# Altium schematic sheet Control Board.SchDoc("Control Board")
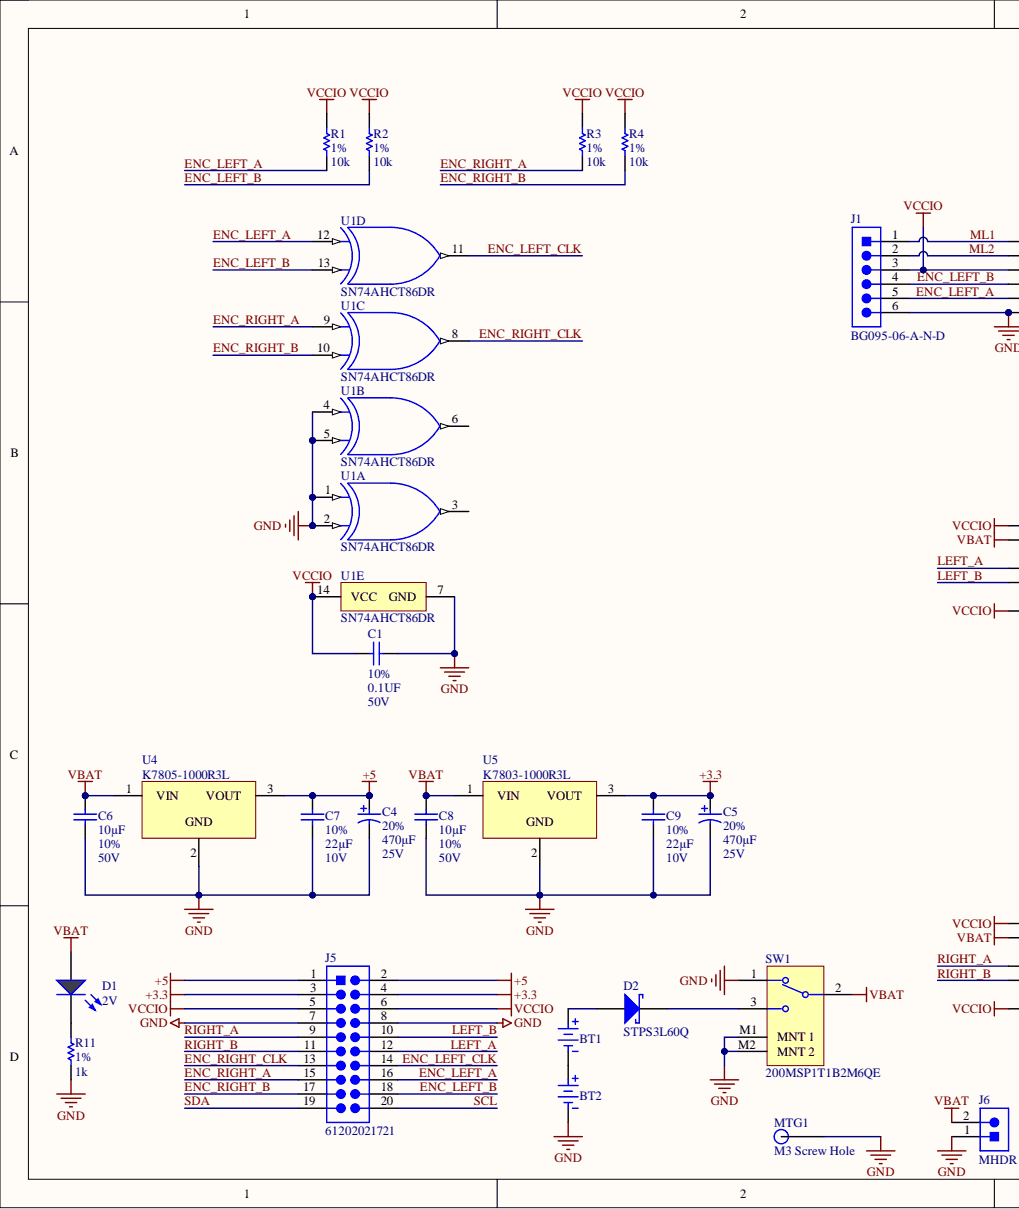
[diagram p0: Control Board.SchDoc, left]
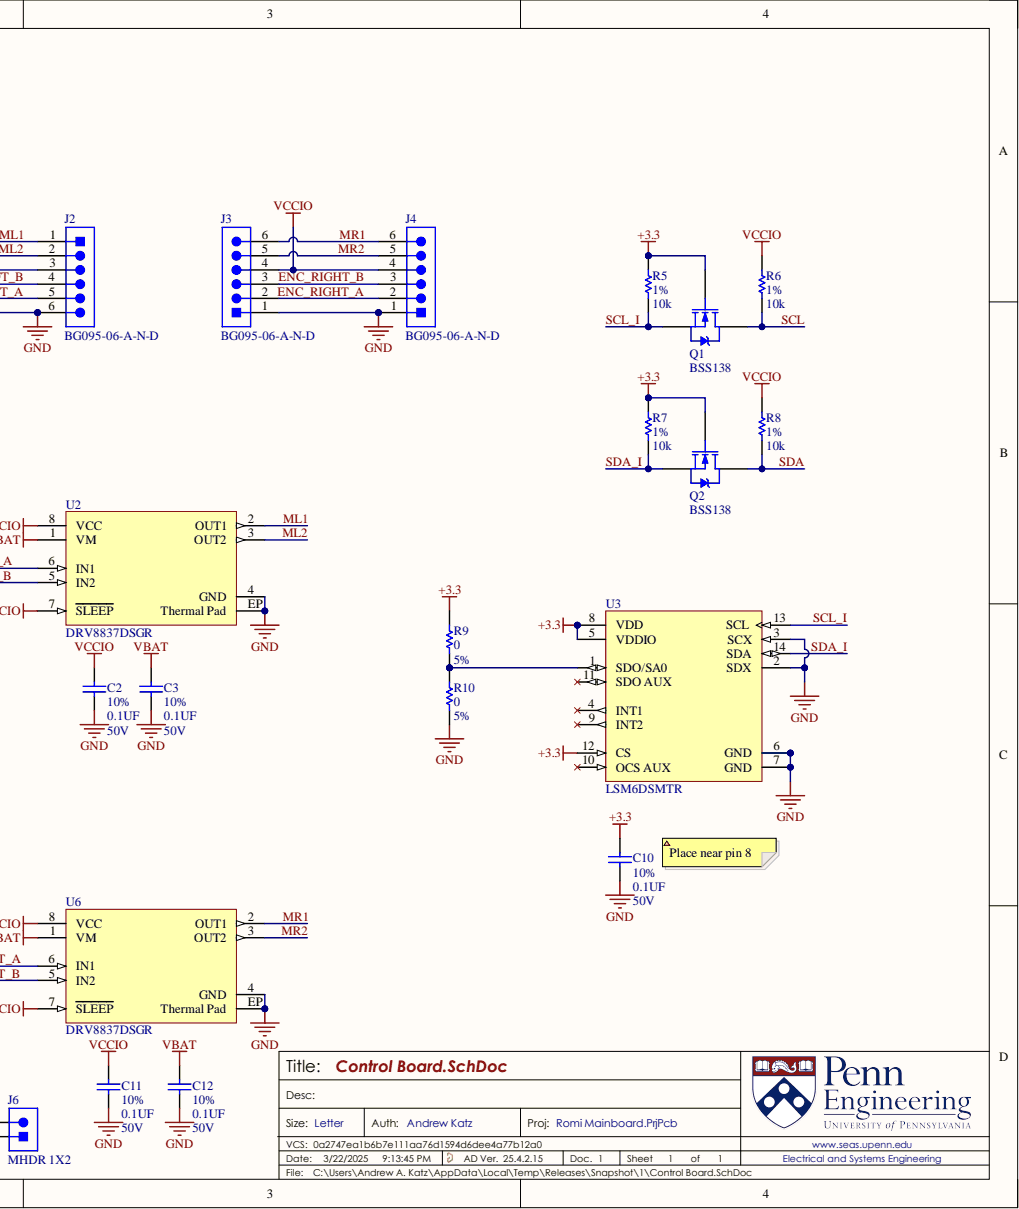
[diagram p1: Control Board.SchDoc, right]

## Parts
BT1  Romi Battery Terminals  [ROMI_BATT]  (value not drawn)
BT2  Romi Battery Terminals  [ROMI_BATT]  (value not drawn)
C1  value 0.1UF  Tolerance: 10%  [0603_CAP]  (hidden comment: CAP 0603 0.1UF 50V 10% X7R)
C2  value 0.1UF  Tolerance: 10%  Voltage: 50V  [0603_CAP]  (hidden comment: CAP 0603 0.1UF 50V 10% X7R)
C3  value 0.1UF  Tolerance: 10%  Voltage: 50V  [0603_CAP]  (hidden comment: CAP 0603 0.1UF 50V 10% X7R)
C4  value 470µF  Tolerance: 20%  Voltage: 25V  [NicCap_10x12.5]  (hidden comment: UVR1H471MHD)
C5  value 470µF  Tolerance: 20%  Voltage: 25V  [NicCap_10x12.5]  (hidden comment: UVR1H471MHD)
C6  value 10µF  Tolerance: 10%  [1206_CAP]  (hidden comment: CAP 1206 10UF 50V 10% X5R)
C7  value 22µF  Tolerance: 10%  Voltage: 10V  [1206_CAP_75H]  (hidden comment: CAP 1206 22UF 10V 10% X7R)
C8  value 10µF  Tolerance: 10%  [1206_CAP]  (hidden comment: CAP 1206 10UF 50V 10% X5R)
C9  value 22µF  Tolerance: 10%  Voltage: 10V  [1206_CAP_75H]  (hidden comment: CAP 1206 22UF 10V 10% X7R)
C10  value 0.1UF  Tolerance: 10%  Voltage: 50V  [0603_CAP]  (hidden comment: CAP 0603 0.1UF 50V 10% X7R)
C11  value 0.1UF  Tolerance: 10%  Voltage: 50V  [0603_CAP]  (hidden comment: CAP 0603 0.1UF 50V 10% X7R)
C12  value 0.1UF  Tolerance: 10%  Voltage: 50V  [0603_CAP]  (hidden comment: CAP 0603 0.1UF 50V 10% X7R)
D1  Forward Voltage: 2V  [LED_0805]  (hidden comment: LSM0805452V)
D2  STPS3L60Q  [DO-15_P0.5in_Horizontal]
J1  BG095-06-A-N-D  [BG095-06-A-N-D-Footprint-1]
J2  BG095-06-A-N-D  [BG095-06-A-N-D-Footprint-1]
J3  BG095-06-A-N-D  [BG095-06-A-N-D-Footprint-1]
J4  BG095-06-A-N-D  [BG095-06-A-N-D-Footprint-1]
J5  61202021721  [FP-61202021721-MFG]
J6  MHDR 1X2  [PH1-02-UA]
MTG1  M3 Screw Hole  [M3 Screw Hole-Footprint-1]  (no BOM)
Q1  BSS138  [SOT-23-3_ONSEMI]
Q2  BSS138  [SOT-23-3_ONSEMI]
R1  value 10k  Tolerance: 1%  [0603_RES]  (hidden comment: RES 0603 10K 1%)
R2  value 10k  Tolerance: 1%  [0603_RES]  (hidden comment: RES 0603 10K 1%)
R3  value 10k  Tolerance: 1%  [0603_RES]  (hidden comment: RES 0603 10K 1%)
R4  value 10k  Tolerance: 1%  [0603_RES]  (hidden comment: RES 0603 10K 1%)
R5  value 10k  Tolerance: 1%  [0603_RES]  (hidden comment: RES 0603 10K 1%)
R6  value 10k  Tolerance: 1%  [0603_RES]  (hidden comment: RES 0603 10K 1%)
R7  value 10k  Tolerance: 1%  [0603_RES]  (hidden comment: RES 0603 10K 1%)
R8  value 10k  Tolerance: 1%  [0603_RES]  (hidden comment: RES 0603 10K 1%)
R9  value 0  Tolerance: 5%  [0603_RES]  (hidden comment: RES 0603 0 5%)
R10  value 0  Tolerance: 5%  [0603_RES]  (hidden comment: RES 0603 0 5%)
R11  value 1k  Tolerance: 1%  [0603_RES]  (hidden comment: RES 0603 1K 1%)
SW1  200MSP1T1B2M6QE  [FP-200MSP1T1B2M6QE-MFG]
U1  SN74AHCT86DR  [SOIC14 (D0014A_N)]  (parts A,B,C,D,E)
U2  DRV8837DSGR  [FP-DSG0008A-MFG]
U3  LSM6DSMTR  [FP-LGA-14L-DM00249496-MFG]
U4  K7805-1000R3L  [K78XX-1000R3L-Footprint-1]
U5  K7803-1000R3L  [K78XX-1000R3L-Footprint-1]
U6  DRV8837DSGR  [FP-DSG0008A-MFG]

## Nets
+3.3: C5.1 C9.2 C10.2 J5.3 J5.4 Q1.1 Q2.1 R5.2 R7.2 R9.2 U3.5(VDDIO) U3.8(VDD) U3.12(CS) U5.3
+5: C4.1 C7.2 J5.1 J5.2 U4.3
GND: BT2.2 C1.2 C2.1 C3.1 C4.2 C5.2 C6.1 C7.1 C8.1 C9.1 C10.1 C11.1 C12.1 J1.6 J2.6 J3.1 J4.1 J5.7 J5.8 J6.1 MTG1.1 R10.1 R11.1 SW1.1 SW1.M1(MNT_1) SW1.M2(MNT_2) U1.1 U1.2 U1.4 U1.5 U1.7(GND) U2.4(GND) U2.EP(Thermal_Pad) U3.2(SDX) U3.3(SCX) U3.6(GND) U3.7(GND) U4.2 U5.2 U6.4(GND) U6.EP(Thermal_Pad)
VBAT: C3.2 C6.2 C8.2 C12.2 D1.A J6.2 SW1.2 U2.1(VM) U4.1 U5.1 U6.1(VM)
VCCIO: C1.1 C2.2 C11.2 J1.3 J2.3 J3.4 J4.4 J5.5 J5.6 R1.2 R2.2 R3.2 R4.2 R6.2 R8.2 U1.14(VCC) U2.7(SLEEP) U2.8(VCC) U6.7(SLEEP) U6.8(VCC)
ENC_LEFT_A: J1.5 J2.5 J5.16 R1.1 U1.12
ENC_LEFT_B: J1.4 J2.4 J5.18 R2.1 U1.13
ENC_LEFT_CLK: J5.14 U1.11
ENC_RIGHT_A: J3.2 J4.2 J5.15 R3.1 U1.9
ENC_RIGHT_B: J3.3 J4.3 J5.17 R4.1 U1.10
ENC_RIGHT_CLK: J5.13 U1.8
LEFT_A: J5.12 U2.6(IN1)
LEFT_B: J5.10 U2.5(IN2)
ML1: J1.1 J2.1 U2.2(OUT1)
ML2: J1.2 J2.2 U2.3(OUT2)
MR1: J3.6 J4.6 U6.2(OUT1)
MR2: J3.5 J4.5 U6.3(OUT2)
RIGHT_A: J5.9 U6.6(IN1)
RIGHT_B: J5.11 U6.5(IN2)
SCL: J5.20 Q1.3 R6.1
SCL_I: Q1.2 R5.1 U3.13(SCL)
SDA: J5.19 Q2.3 R8.1
SDA_I: Q2.2 R7.1 U3.14(SDA)
NetBT1_1: BT1.1 D2.A
NetBT1_2: BT1.2 BT2.1
NetD1_K: D1.K R11.2
NetD2_K: D2.K SW1.3
NetR9_1: R9.1 R10.2 U3.1(SDO/SA0)

Not connected: U1 3 6; U3 4(INT1) 9(INT2) 10(OCS_AUX) 11(SDO_AUX)

## Library data of the parts
C1-C3 C10-C12 = CMP-011-000223-2: Capacitor Surface Mount 0603 Footprint 0.1UF 50V 10% Tolerance Ceramic X7R; Manufacturer: Various; Description in Visual: Cap SMT 0603 0.1UF 50V 10%  Cer X7R; PCB Package: 0603; Component Kind: Standard; JLCPCB Part #: C14663; Library Name: University of Pennsylvania (ESE Labs); Manufacturer P/N: CAP 0603 0.1UF 50V 10% X7R; Mount: SMT; P/N: CAP 0603 0.1UF 50V 10% X7R; Type: Ceramic X7R
  C1: Voltage: 50V
C4 C5 = CMP-00366-1: Capacitor, Aluminum Electrolytic, Cap 470uF 50V 20%, Radial 12.5X20 LS 5 mm; Capacitor Type: Aluminium; Leakage Current: 705uA; Polarity: Polarized; Ripple Current: 760mA; Tan Delta: 0.12; Case/Package: THT; Component Kind: Standard; Diameter: 12.5mm; Dielectric Material: Aluminium; JESD-609 Code: e3; Length: 20mm; Library Name: University of Pennsylvania (ESE Labs); Max Operating Temperature: 85°C; Min Operating Temperature: -40°C; Mount: THT; Mounting Technology: Through Hole; Package Shape: Cylindrical; Package Style: RadialMeter; Packaging: Bulk; Pins: 2; Rated DC Voltage (URdc): 50V; Reach SVHC Compliant: No; RoHS: Yes; RoHS Compliant: Yes; Terminal Finish: Tin; Terminal Pitch: 5mm; Termination: Wire; Tolerance  (fill in as +-): 20%; Tolerance (fill in as +-): 20%
C6 C8 = CMP-001-00003-3: Capacitor Surface Mount 1206 Footprint 10UF 25V 10% Tolerance Ceramic X5R; Manufacturer: Various; Description in Visual: Cap SMT 1206 10UF 50V 10%  Cer X5R; PCB Package: 1206; Capacitor Type: Ceramic; Case/Package: 1206; Component Kind: Standard; JLCPCB Part #: C13585; Library Name: University of Pennsylvania (ESE Labs); Manufacturer P/N: CAP 1206 10UF 50V 10% X5R; Mount: SMT; P/N: CAP 1206 10UF 50V 10% X5R; RoHS: Yes; Type: Ceramic X5R; Voltage: 50V
C7 C9 = CMP-011-000660-2: Capacitor Surface Mount 1206 Footprint 22UF 10V 10% Tolerance Ceramic X7R; Manufacturer: Various; Description in Visual: Cap SMT 1206 22UF 10V 10%  Cer X7R; PCB Package: 1206; Capacitor Type: Ceramic; Case/Package: 1206; Component Kind: Standard; JLCPCB Part #: C5672; Library Name: University of Pennsylvania (ESE Labs); Manufacturer P/N: CAP 1206 22UF 10V 10% X7R; Mount: SMT; P/N: CAP 1206 22UF 10V 10% X7R; RoHS: Yes; Type: Ceramic X7R
D1 = CMP-00038-3: Single Color LED, Green, 0805; Test Current: 20mA; Component Kind: Standard; JLCPCB Part #: C2297; Library Name: University of Pennsylvania (ESE Labs); RoHS Compliant: Yes
D2 = CMP-00365-1: Rectifier Diode Schottky 60V 3A 2-Pin DO-15 T/R; Application(s): POWER; Case Connection: Isolated; Diode Element Material: SILICON; Forward Voltage: 590mV; Non-rep Pk Forward Current-Max: 100A; Number of Elements: 1; Package Body Material: Plastic; Qualification Status: Not Qualified; Surface Mount: No; Component Kind: Standard; Diode Type: RECTIFIER; JEDEC-95 Code: DO-15; JESD-30 Code: O-PALF-W2; JESD-609 Code: e3; Library Name: University of Pennsylvania (ESE Labs); Max Operating Temperature: 150°C; Max Output current: 3A; Moisture Sensitivity Level: 1; Number of Phases: 1; Package Shape: Round; Package Style: LONG FORMMeter; Pins: 2; Reach SVHC Compliant: No; Rep Pk Reverse Voltage-Max: 60V; RoHS Compliant: Yes; Technology: SCHOTTKY; Terminal Finish: Tin; Terminal Form: Wire; Terminal Position: AXIAL
J1-J4 = CMP-00360-1: Board Connector, 6 Contact(s), 1 Row(s), Female, Straight, 0.1 inch Pitch, Solder Terminal, Locking Low Profile; Manufacturer Series: BG095; Body Depth: 0.197inch; Body Length: 0.62inch; Contact Pattern: RECTANGULAR; Contact Resistance: 20mR; Contact Style: SQ PIN-SKT; Dielectric Withstanding Voltage (AC): 600V; Insulation Resistance: 1GR; Number Of Connectors: 1; Number of Contacts: 6; Polarization Key: POLARIZED HOUSING; Rated Current (Signal): 3A; Reference Standard: UL; Reliability: COMMERCIAL; Body Breadth: 0.1inch; Component Kind: Standard; Connector Type: BOARD CONNECTOR; Contact Finish - Mating: AU; Contact Finish - Termination: Gold (Au) - with Nickel (Ni) barrier; Contact Gender: Female; Contact Material: Bronze; Insulation Material: Nylon; JESD-609 Code: e4; Library Name: University of Pennsylvania (ESE Labs); Mating Contact Pitch: 0.1inch; Max Operating Temperature: 105°C; Min Operating Temperature: -40°C; Mounting Option 1: LOCKING; Mounting Style: STRAIGHT; Mounting Technology: Board; Number Of PCB Rows: 1; Number of Rows Loaded: 1; PCB Contact Pattern: RECTANGULAR; Reach SVHC Compliant: Yes; RoHS Compliant: Yes; Terminal Length: 0.118inch; Terminal Pitch: 2.54mm; Termination: Solder
J5 = CMP-00359-1: Board Connector, 20 Contact(s), 2 Row(s), Male, Right Angle, 0.1 inch Pitch, Solder Terminal, Locking, Black Insulator; Body Breadth: 0.358inch; Body Depth: 0.521inch; Body Length: 1.302inch; Contact Pattern: RECTANGULAR; Contact Resistance: 20mR; Contact Style: SQ PIN-SKT; Dielectric Withstanding Voltage (AC): 500V; DIN Conformance: No; Insulation Resistance: 1GR; Number Of Connectors: 1; Number of Contacts: 20; Number Of PCB Rows: 2; Option: General Purpose; PCB Contact Row Spacing: 2.54mm; Polarization Key: POLARIZED HOUSING; Rated Current (Signal): 3A; Reference Standard: UL; Reliability: COMMERCIAL; Component Kind: Standard; Connector Type: BOARD CONNECTOR; Contact Finish - Mating: GOLD; Contact Finish - Termination: Nickel/Gold (Ni/Au); Contact Gender: Male; Contact Material: Copper Alloy; Filter Feature: No; Flammability Rating: UL94 V-0; IEC Conformance: No; Insulation Color: Black; Insulation Material: Polybutylene; JESD-609 Code: e4; Library Name: University of Pennsylvania (ESE Labs); Mating Contact Pitch: 0.1inch; Mating Contact Row Spacing: 0.1inch; Max Operating Temperature: 125°C; MIL Conformance: No; Min Operating Temperature: -40°C; Mixed Contacts: No; Mounting Option 1: LOCKING; Mounting Style: RIGHT ANGLE; Mounting Technology: Board; Number of Positions: 20; Number of Rows: 2; Number of Rows Loaded: 2; PCB Contact Pattern: RECTANGULAR; Pitch: 2.54mm; Reach SVHC Compliant: Yes; RoHS Compliant: Yes; Terminal Length: 0.118inch; Terminal Pitch: 2.54mm; Termination: Solder
J6 = CMP-000021-1: Header, 2-Pin, 0.1in Pitch, Male; Component Kind: Standard; Library Name: University of Pennsylvania (ESE Labs)
MTG1 = CMP-00028-2: Clearance Fit for M3 Screw Hole; PCB Mounting for M3 Screw; Library Name: University of Pennsylvania (ESE Labs)
Q1 Q2 = CMP-00090-4: Small Signal Field-Effect Transistor, 0.22A I(D), 50V, 1-Element, N-Channel, Silicon, Metal-oxide Semiconductor FET; I_D (Max Continuous): 220mA; V_GSS (Max): 20V; Component Kind: Standard; JLCPCB Part #: C52895; Library Name: University of Pennsylvania (ESE Labs); V_DSS (Max): 50V
R1-R8 = CMP-005-002156-2: Resistor Surface Mount, 10K Ohms, 0603 Footprint, 1% Tolerance 0.1W; Manufacturer: Various; Description in Visual: Res SMT 10K 0603  1%  0.1W; PCB Package: 0603; Component Kind: Standard; JLCPCB Part #: C25804; Library Name: University of Pennsylvania (ESE Labs); Manufacturer P/N: RES 0603 10K 1%; Mount: SMT; Power Rating: 0.1W
R9 R10 = CMP-005-001964-2: Resistor Surface Mount, 0 Ohms, 0603 Footprint, 5% Tolerance 0.1W; Manufacturer: Various; Manufacturer P/N: RES 0603 0R 5%; Description in Visual: Res SMT 0 0603  5%  0.1W; PCB Package: 0603; Component Kind: Standard; JLCPCB Part #: C21189; Library Name: University of Pennsylvania (ESE Labs); Mount: SMT; Power Rating: 0.1W
R11 = CMP-005-002503-2: Resistor Surface Mount, 1K Ohms, 0603 Footprint, 1% Tolerance 0.1W; Manufacturer: Various; Description in Visual: Res SMT 1K 0603  1%  0.1W; PCB Package: 0603; Component Kind: Standard; JLCPCB Part #: C21190; Library Name: University of Pennsylvania (ESE Labs); Manufacturer P/N: RES 0603 1K 1%; Mount: SMT; Power Rating: 0.1W
SW1 = CMP-00364-1: Toggle Switch, SPDT, On-on, 3A, 28VDC, 5 PCB Hole Cnt, Solder Terminal, Toggle Actuator, Through Hole-right Angle; Actuator Angle: 25°; Actuator Finish: Chrome; Actuator Length: 0.37inch; Actuator Material: Brass; Body Breadth: 5.08inch; Body Length or Diameter: 8.128mm; Bushing Length: 0.22inch; Center Contact Plating: Silver; Contact AC Max Rating R Load: 1A@250VAC; Contact Current(AC)-Max: 1A; Contact DC Max Rating R Load: 3A@28VDC; Contact Resistance: 0.01mR; Contact Voltage(AC)-Max: 250V; Contact Voltage(DC)-Max: 28V; Dielectric Withstand Volts: 1000VRMSV; Electrical Life: 30000Cycles; End Contact Material: Copper Alloy; Insulation Resistance: 1GR; PCB Hole Count: 5; Sealing: EPOXY; Actuator Type: Toggle; Circuit: SPDT; Component Kind: Standard; Contact Current(DC)-Max: 3A; Contact Function: On-On; Contact Material: Copper Alloy; End Contact Plating: Silver; Housing Material: Stainless Steel; Library Name: University of Pennsylvania (ESE Labs); Manufacturer Series: 200MSP1T1B2M6QE; Max Operating Temperature: 85°C; Min Operating Temperature: -30°C; Mounting Technology: Through Hole; Reach SVHC Compliant: Yes; Switch Function: ON-ON; Switch Type: Toggle; Terminal Length: 0.13inch; Terminal Material: Copper Alloy; Termination: Solder; Type: Toggle
U1 = CMP-00370-2: XOR Gate, AHCT/VHCT/VT Series, 4-Func, 2-Input, CMOS, PDSO14; Channels: 4; Load Capacitance (CL): 50pF; Max I(ol): 8mA; Max Supply Voltage: 5.5V; Min Supply Voltage: 4.5V; Nominal Supply Voltage: 5V; Number of Inputs: 2; Package Body Material: Plastic; Package Equivalence Code: SOP14,.25; Prop. Delay@Nom-Sup: 10ns; Qualification Status: Not Qualified; Schmitt Trigger: No; Case/Package: SOP; Component Kind: Standard; Height - Seated (Max): 1.75mm; JESD-30 Code: R-PDSO-G14; JESD-609 Code: e4; JLCPCB Part #: C354155; Length: 8.65mm; Library Name: University of Pennsylvania (ESE Labs); Logic Type: XOR GATE; Max Operating Temperature: 125°C; Min Operating Temperature: -40°C; Moisture Sensitivity Level: 1; Package Shape: Rectangular; Package Style: SMALL OUTLINEMeter; Packaging: Tape and Reel; Peak Reflow Temperature (Cel): 260°C; Pins: 14; Power Supplies: 5V; Propagation Delay (tpd): 10ns; Reach SVHC Compliant: Yes; RoHS Compliant: Yes; Surface Mount: Yes; Technology: CMOS; Temperature Grade: AUTOMOTIVE; Terminal Finish: Nickel; Terminal Form: Gull Wing; Terminal Pitch: 1.27mm; Terminal Position: DUAL; Width: 3.9mm
U2 U6 = CMP-00361-2: Brush DC Motor Controller, 1.8A, PDSO8; Channels: 1; Max Supply Voltage: 7V; Min Supply Voltage: 1.8V; Nominal Supply Voltage: 3V; Package Body Material: Plastic; Power Supplies: 3.5V; Qualification Status: Not Qualified; Supply Current-Max (Isup): 1.5mA; Case/Package: HVSON; Component Kind: Standard; Height - Seated (Max): 0.8mm; JESD-30 Code: S-PDSO-N8; JESD-609 Code: e4; JLCPCB Part #: C39159; Length: 2mm; Library Name: University of Pennsylvania (ESE Labs); Max Operating Temperature: 85°C; Max Output current: 1.8A; Min Operating Temperature: -40°C; Moisture Sensitivity Level: 2; Package Shape: Square; Package Style: SMALL OUTLINE, HEAT SINK/SLUG, VERY THIN PROFILEMeter; Peak Reflow Temperature (Cel): 260°C; Pins: 8; Reach SVHC Compliant: Yes; RoHS Compliant: Yes; Surface Mount: Yes; Temperature Grade: INDUSTRIAL; Terminal Finish: Nickel; Terminal Form: No Lead; Terminal Pitch: 0.5mm; Terminal Position: DUAL; Throw Configuration: BRUSH DC MOTOR CONTROLLER; Width: 2mm
U3 = CMP-000052-5: Accelerometer, Gyroscope, Temperature, 6 Axis Sensor I²C, SPI Output; Analog IC - Other Type: ANALOG CIRCUIT; Number of Functions: 1; Package Body Material: Plastic; Supply Voltage-Max (Vsup): 3.6V; Supply Voltage-Min (Vsup): 1.71V; Supply Voltage-Nom (Vsup): 1.8V; Component Kind: Standard; Height - Seated (Max): 0.86mm; JESD-609 Code: e4; JLCPCB Part #: C94048; Length: 3mm; Library Name: University of Pennsylvania (ESE Labs); Max Operating Temperature: 85°C; Min Operating Temperature: -40°C; Moisture Sensitivity Level: 3; Number of Pins: 14; Package Code: VFLGA; Package Shape: Rectangular; Package Style: GRID ARRAY, VERY THIN PROFILE, FINE PITCHMeter; Peak Reflow Temperature (Cel): 260°C; REACH SVHC: Yes; RoHS Compliant: Yes; Surface Mount: Yes; Technology: CMOS; Temperature Grade: INDUSTRIAL; Terminal Finish: Nickel; Terminal Form: BUTT; Terminal Pitch: 0.5mm; Terminal Position: BOTTOM; Width: 2.5mm
U4 = CMP-003601-2: DC-DC Regulated Power Supply Module, 1 Output, 5V, Hybrid; Component Kind: Standard; Library Name: University of Pennsylvania (ESE Labs)
U5 = CMP-00363-1: DC-DC Regulated Power Supply Module, 1 Output, 3.3V, Hybrid; Component Kind: Standard; Library Name: University of Pennsylvania (ESE Labs)
BT1 BT2 = Romi Battery Terminals: Component Kind: Standard; Library Name: Romi Parts.SchLib
